# Altium schematic sheet Excavator_S01_P01.SchDoc
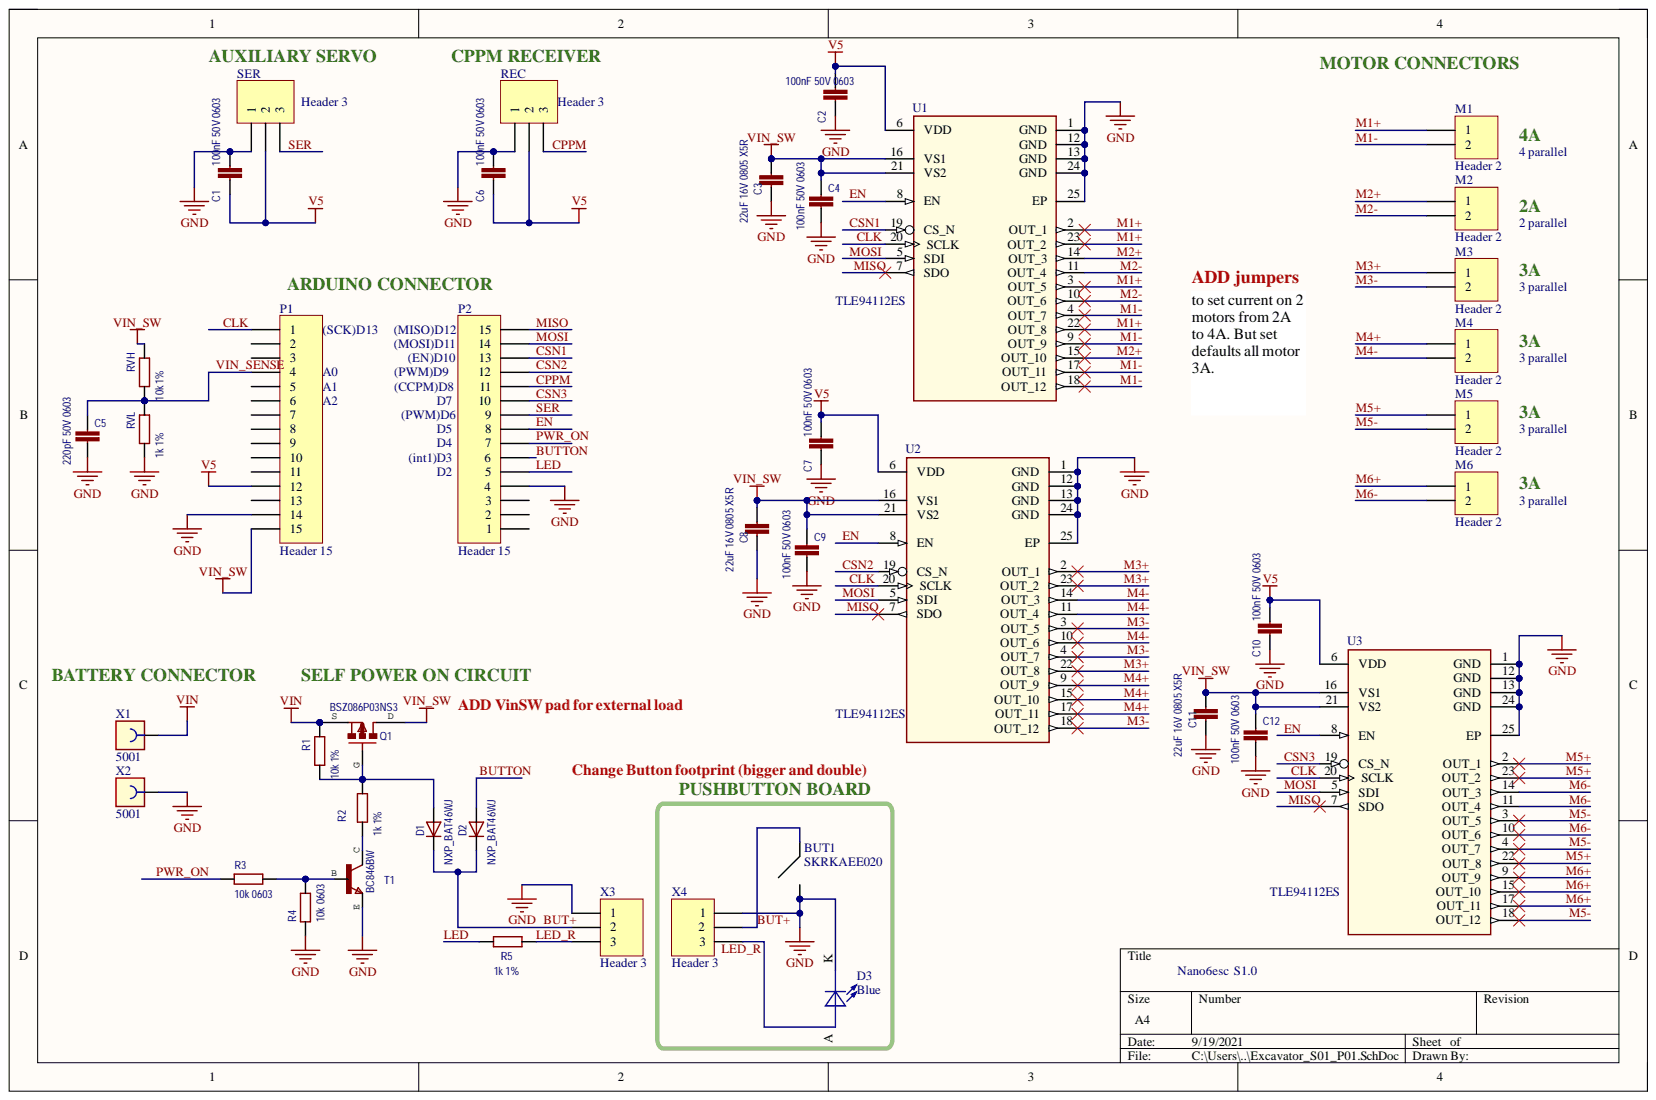
[diagram p0: Excavator_S01_P01.SchDoc]

## Parts
BUT1  SKRKAEE020  [C-SMD-4.8x3.3-0]
C1  100nF 50V 0603  [C0603]
C2  100nF 50V 0603  [C0603]
C3  22uF 16V 0805 X5R  [C0805]
C4  100nF 50V 0603  [C0603]
C5  220pF 50V 0603  [C0603]
C6  100nF 50V 0603  [C0603]
C7  100nF 50V 0603  [C0603]
C8  22uF 16V 0805 X5R  [C0805]
C9  100nF 50V 0603  [C0603]
C10  100nF 50V 0603  [C0603]
C11  22uF 16V 0805 X5R  [C0805]
C12  100nF 50V 0603  [C0603]
D1  NXP_BAT46WJ  [SOD323F]
D2  NXP_BAT46WJ  [SOD323F]
D3  Blue  [LED 0603 ROSSO]
M1  Header 2  [HDR1X2]
M2  Header 2  [HDR1X2]
M3  Header 2  [HDR1X2]
M4  Header 2  [HDR1X2]
M5  Header 2  [HDR1X2]
M6  Header 2  [HDR1X2]
P1  Header 15  [HDR1X15]
P2  Header 15  [HDR1X15]
Q1  BSZ086P03NS3  [PG-TSDSON-8 SGD]
R1  10k 1%  [R0603]
R2  1k 1%  [R0603]
R3  10k 0603  [R0603]
R4  10k 0603  [R0603]
R5  1k 1%  [R0603]
REC  Header 3  [HDR1X3]
RVH  10k 1%  [R0603]
RVL  1k 1%  [R0603]
SER  Header 3  [HDR1X3]
T1  BC846BW  [SOT323 -BEC]
U1  TLE94112ES  [SOP65P600X115-25N-1]
U2  TLE94112ES  [SOP65P600X115-25N-1]
U3  TLE94112ES  [SOP65P600X115-25N-1]
X1  5001  [CON-THT-TP-5001]
X2  5001  [CON-THT-TP-5001]
X3  Header 3  [HDR1X3]
X4  Header 3  [HDR1X3]

## Nets
GND: BUT1.2 C1.2 C2.1 C3.1 C4.1 C5.1 C6.2 C7.1 C8.1 C9.1 C10.1 C11.1 C12.1 D3.K P1.14 P2.4 R4.2 REC.1 RVL.1 SER.1 T1.E U1.1(GND) U1.12(GND) U1.13(GND) U1.24(GND) U1.25(EP) U2.1(GND) U2.12(GND) U2.13(GND) U2.24(GND) U2.25(EP) U3.1(GND) U3.12(GND) U3.13(GND) U3.24(GND) U3.25(EP) X2.1 X3.1 X4.1
V5: C1.1 C2.2 C6.1 C7.2 C10.2 P1.12 REC.2 SER.2 U1.6(VDD) U2.6(VDD) U3.6(VDD)
VIN: Q1.S R1.2 X1.1
VIN_SW: C3.2 C4.2 C8.2 C9.2 C11.2 C12.2 P1.15 Q1.D RVH.2 U1.16(VS1) U1.21(VS2) U2.16(VS1) U2.21(VS2) U3.16(VS1) U3.21(VS2)
BUT+: BUT1.1 D1.K D2.K X3.2 X4.2
BUTTON: D2.A P2.6
CLK: P1.1 U1.20(SCLK) U2.20(SCLK) U3.20(SCLK)
CPPM: P2.11 REC.3
CSN1: P2.13 U1.19(CS_N)
CSN2: P2.12 U2.19(CS_N)
CSN3: P2.10 U3.19(CS_N)
EN: P2.8 U1.8(EN) U2.8(EN) U3.8(EN)
LED: P2.5 R5.1
LED_R: R5.2 X3.3
M1+: M1.1 U1.2(OUT_1) U1.3(OUT_5) U1.22(OUT_8) U1.23(OUT_2)
M1-: M1.2 U1.4(OUT_7) U1.9(OUT_9) U1.17(OUT_11) U1.18(OUT_12)
M2+: M2.1 U1.14(OUT_3) U1.15(OUT_10)
M2-: M2.2 U1.10(OUT_6) U1.11(OUT_4)
M3+: M3.1 U2.2(OUT_1) U2.22(OUT_8) U2.23(OUT_2)
M3-: M3.2 U2.3(OUT_5) U2.4(OUT_7) U2.18(OUT_12)
M4+: M4.1 U2.9(OUT_9) U2.15(OUT_10) U2.17(OUT_11)
M4-: M4.2 U2.10(OUT_6) U2.11(OUT_4) U2.14(OUT_3)
M5+: M5.1 U3.2(OUT_1) U3.22(OUT_8) U3.23(OUT_2)
M5-: M5.2 U3.3(OUT_5) U3.4(OUT_7) U3.18(OUT_12)
M6+: M6.1 U3.9(OUT_9) U3.15(OUT_10) U3.17(OUT_11)
M6-: M6.2 U3.10(OUT_6) U3.11(OUT_4) U3.14(OUT_3)
MISO: P2.15 U1.7(SDO) U2.7(SDO) U3.7(SDO)
MOSI: P2.14 U1.5(SDI) U2.5(SDI) U3.5(SDI)
PWR_ON: P2.7 R3.2
SER: P2.9 SER.3
VIN_SENSE: C5.2 P1.4 RVH.1 RVL.2
NetD1_A: D1.A Q1.G R1.1 R2.2
NetD3_A: D3.A X4.3
NetR2_1: R2.1 T1.C
NetR3_1: R3.1 R4.1 T1.B

Not connected: P1 2 3 5 6 7 8 9 10 11 13; P2 1 2 3

## Library data of the parts
BUT1 = IFX_Default: Infineon Default Schematic Library Template; Alternate View: Yes or No?; Symbol Type: Infineon Default Schematic Library Template (Same as Description); Component Kind: Standard; Library Name: button.SchLib; Multiple Parts: Yes or No?
C1 C2 C4-C7 C9 C10 C12 = C-EUC0402: CAPACITOR, European symbol; Component Kind: Standard; Library Name: TLD5542-1MultiCh_V4.3.IntLib
C3 C8 C11 = C-EUC0805: CAPACITOR, European symbol; Component Kind: Standard; Library Name: TLD5542-1MultiCh_V4.3.IntLib
D1 D2 = NXP_BAT46WJ: NXP BAT46WJ Single Barrier Schottky Diode. If = 250mA; Vr = 100V; Component Kind: Standard; Library Name: TLD5542-1MultiCh_V4.3.IntLib
D3 = (no symbol name): Surface Mount LED, Super Red, 633nm; Manufacturer: OSRAM Opto Semiconductors; Manufacturer Component Status: Active; Manufacturer Order Number: LS Q976-NR-1; Article Number: 393643; Automotive Qualified: No; Combined Parameters: Vf:2V;If:25mA;Ur:12V;Ir:100uA;Pmax:-; Legacy DeviceID: DIO01300122; Second Source allowed: Yes; Style: Single; Symbol Type: Standard LED Symbol, 1 Cathode, 2 Anode; Version Number: V01; Voltage DC (max): na; Application: Standard; Component Kind: Standard; ComponentLink1Description: Vendor WebSite; ComponentLink1URL: http://www.osram-os.com; ComponentLink2Description: Datasheet; ComponentLink2URL: http://webnetprod.muc.infineon.com/pcbportal_prod/WorkFlows/AltiumComponent/StandardDocumentation?Versionid=393644; Legacy PartNumber: DIO01300122; Library Name: TLD5542-1MultiCh_V4.3.IntLib; Package Description: LED, Side Concave, 2 pin, 1.60mm W X 0.80mm L X 0.80mm H; PackageReference: 0603; Part Type: Diode; PLMStatus: Active; RoHS Compliant: Y; Supplier 1: Farnell; Supplier 2: DigiKey; Supplier 3: Mouser; Supplier Part Number 2: 475-2512-2-ND; Supplier Part Number 3: 720-LSQ976-NR-1; Temperature max: 85; Temperature min: -30; Temperature Range: Military; Type: LED; Value: Red
M1-M6 = Header 2: Header, 2-Pin; Component Kind: Standard; LatestRevisionDate: 17-Jul-2002; LatestRevisionNote: Re-released for DXP Platform.; Library Name: Miscellaneous Connectors.IntLib; Signal Integrity: Connector; Publisher: Altium Limited
P1 P2 = Header 15: Header, 15-Pin; Component Kind: Standard; LatestRevisionDate: 17-Jul-2002; LatestRevisionNote: Re-released for DXP Platform.; Library Name: Miscellaneous Connectors.IntLib; Signal Integrity: Connector; Publisher: Altium Limited
Q1 = IPG20N04S4-08: Component Kind: Standard; Library Name: TLD5542-1MultiCh_V4.3.IntLib
R1-R5 RVH RVL = R-EU_R0402: RESISTOR, European symbol; Component Kind: Standard; Library Name: TLD5542-1MultiCh_V4.3.IntLib
REC SER X3 X4 = Header 3: Header, 3-Pin; Component Kind: Standard; LatestRevisionDate: 17-Jul-2002; LatestRevisionNote: Re-released for DXP Platform.; Library Name: Miscellaneous Connectors.IntLib; Signal Integrity: Connector; Publisher: Altium Limited
T1 = NPNSOT323: NPN TRANSISTOR; Component Kind: Standard; Library Name: TLD5542-1MultiCh_V4.3.IntLib
U1-U3 = (no symbol name): Protected Twelve-Fold Half-Bridge Driver; Manufacturer: Infineon Technologies; Component Kind: Standard; Library Name: TLE94112ES.SchLib; ManufacturerPartNumber: TLE94112ES; PackageReference: PG-SSOP-24
X1 X2 = 378249: Test Point THT, Black; Legacy PartNumber: CON01500117; Manufacturer: Keystone Electronics Corp.; Alternate View: Yes; Automotive Qualified: No; Combined Parameters: Board-to-Cable None (onboard);1x1;Mounting:THT;Orient:None (onboard);Shielded:No; ComponentStatus: Released; Database Table Name: TPartsAll; Legacy DeviceID: KEY_PN5001; PLMStatus: Active; QuickPick: None (onboard) / Board-to-Cable; Style: Looped Terminal; Symbol Type: Connector, 1 Lead, Female; Version Number: V01; Voltage DC (max): na; Application: Test; Article Number: 378249; Component Kind: Standard; ComponentLink1Description: Vendor WebSite; ComponentLink1URL: http://www.keyelco.com/; ComponentLink2Description: Datasheet; ComponentLink2URL: http://webnetprod.muc.infineon.com/PCBPortal_Prod/WorkFlows/AltiumComponent/StandardDocumentation?Versionid=378250; Library Name: PCBLibraryData.SVNDbLib; Manufacturer Order Number: 5001; Multiple Parts: No; Package Description: Test Point;1.27mm lead width,round,1.20mm Dia X 4.57mm H body; Part Type: Connector; ROHS Compliant: Y; Second Source allowed: Yes; Supplier 1: Farnell; Supplier 2: DigiKey; Supplier 3: Mouser; Supplier Part Number 1: 1463077; Supplier Part Number 2: 36-5001-ND; Supplier Part Number 3: 534-5001; Temperature max: 0; Temperature min: 0; Temperature Range: Military; Type: Board-to-Cable; Value: 5001
